# Altium schematic sheet lv_power_indicator_light_cc.SchDoc(lv_power_indicator_light_cc)
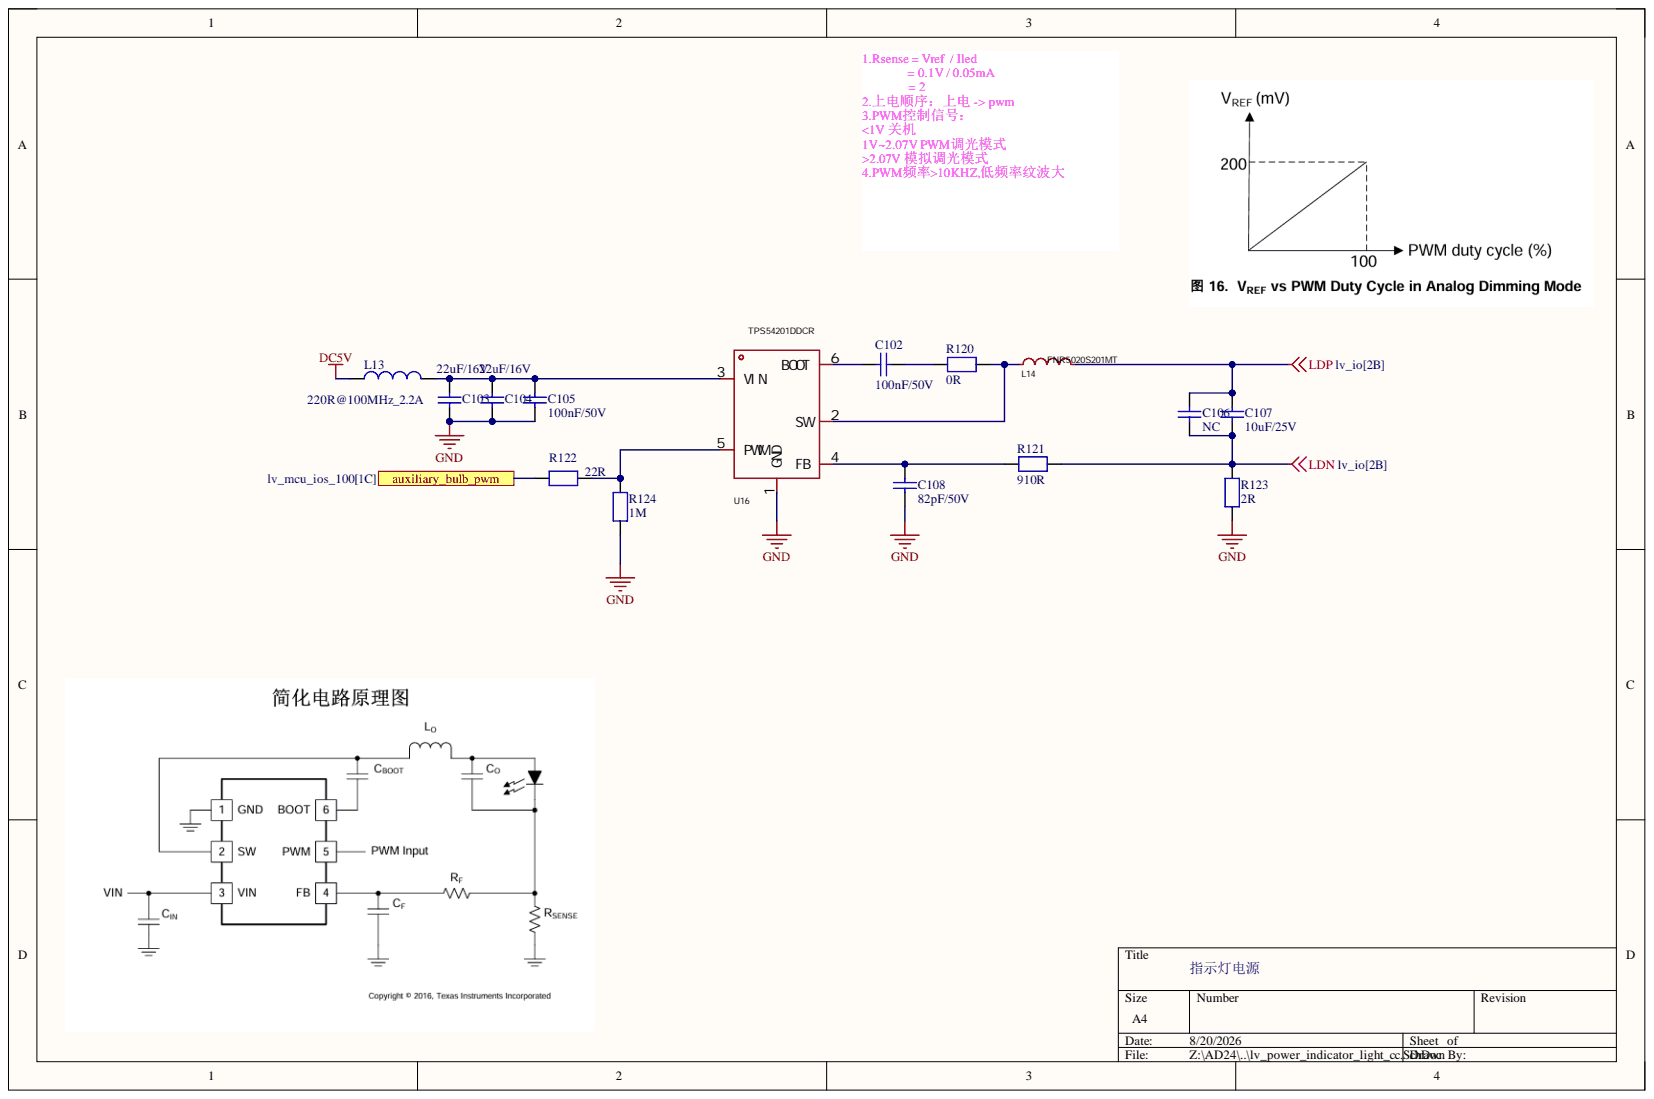
[diagram p0: lv_power_indicator_light_cc.SchDoc]

## Parts
C102  100nF/50V  [C0402]
C103  22uF/16V  [C1206]
C104  22uF/16V  [C1206]
C105  100nF/50V  [C0402]
C106  NC  [C0603]
C107  10uF/25V  [C0603]
C108  82pF/50V  [C0402]
L13  220R@100MHz_2.2A  [L0603]
L14  FNR5020S201MT  [IND-SMD_L5.0-W5.0_FNR50XXS]
R120  0R  [R0603]
R121  910R  [R0603]
R122  22R  [R0402]
R123  2R  [0805]
R124  1M  [R0603]
U16  TPS54201DDCR  [TSOT-23-6_L2.9-W1.6-P0.95-LS2.8-BR]

## Ports
auxiliary_bulb_pwm

## Nets
DC5V: L13.2
GND: C103.1 C104.1 C105.2 C108.2 R123.2 R124.1 U16.1(GND)
LDN: C106.1 C107.1 R121.1 R123.1
LDP: C106.2 C107.2 L14.2
auxiliary_bulb_pwm: R122.1 ; port auxiliary_bulb_pwm
NetC102_1: C102.1 U16.6(BOOT)
NetC102_2: C102.2 R120.2
NetC103_2: C103.2 C104.2 C105.1 L13.1 U16.3(VIN)
NetC108_1: C108.1 R121.2 U16.4(FB)
NetL14_1: L14.1 R120.1 U16.2(SW)
NetR122_2: R122.2 R124.2 U16.5(PWM)

## Library data of the parts
C102 = Capacitor: 50V, 5%, X7R; Manufacturer: muRata; Manufacturer_Part_Number: GRM155R71H104KE14D; Component Kind: Standard; ComponentLink1Description: Datasheet; ComponentLink1URL: http://tunmcdsvn.tun.st.com/Component_Datasheet_Library/Trunk/Capacitors/Yageo_Capacitor_NP0_16V-to-50V; Library Name: HiPin_Library_AD.IntLib
C103 C104 = Capacitor_1: 16V, X5R, 10%; Internal_Part_Number: MCD-00009; Manufacturer_Part_Number: GRM31CR61C226KE15L; Component Kind: Standard; ComponentLink1Description: Yageo_Capacitor_X5R-series.pdf; ComponentLink1URL: http://tunmcdsvn.tun.st.com/Component_Datasheet_Library/Trunk/Capacitors/Yageo_Multilayer_capacitor_X5R_series_100pF_to_100uF; Library Name: HiPin_Library_AD.IntLib
C105 = Capacitor_2: 50V, X7R , 10%; Manufacturer: muRata; Manufacturer_Part_Number: GRM155R71H104KE14D; Component Kind: Standard; ComponentLink1Description: Datasheet; ComponentLink1URL: http://tunmcdsvn.tun.st.com/Component_Datasheet_Library/Trunk/Capacitors/Yageo_Multilayer_capacitor_X5R_series_100pF_to_100uF; Library Name: HiPin_Library_AD.IntLib
C106 C107 = Capacitor_1: 25V, X5R, 20%; Manufacturer: muRata; Manufacturer_Part_Number: GRM188R61E106MA73D; Component Kind: Standard; ComponentLink1Description: Yageo_Capacitor_X5R-series.pdf; ComponentLink1URL: http://tunmcdsvn.tun.st.com/Component_Datasheet_Library/Trunk/Capacitors/Yageo_Multilayer_capacitor_X5R_series_100pF_to_100uF; Library Name: HiPin_Library_AD.IntLib
C108 = Capacitor: 50V,1%, NP0; Manufacturer: YAGEO; Manufacturer_Part_Number: CC0402FRNPO9BN820; Component Kind: Standard; Library Name: HiPin_Library_AD.IntLib
L13 = MCD-00047: SMD Chip ferrite bead, 220R@100MHz +/-25%,2.2A, 50mohm; Manufacturer: Sunlord; Manufacturer_Part_Number: UPZ1608U221-2R2TF; Database Table Name: MCD_PARTS$; Component Kind: Standard; ComponentLink1Description: Datasheet; ComponentLink1URL: http://tunmcdsvn.tun.st.com/Component_Datasheet_Library/Trunk/Inductors/TaiTech_Bead_FCM1608_series; Internal_Part_Number: MCD-00047; Library Name: MCD_PARTS_database.DbLib
L14 = FNR5020S201MT: 电感，330mA，2.6Ω，20%; Manufacturer: cjiang(长江微电); Add into BOM: yes; DC Resistance (DCR): 2.6Ω; Rated Current: 450mA; Saturation Current (Isat): 330mA; Tolerance: ±20%; Value: 200uH; Component Kind: Standard; Convert to PCB: yes; Datasheet: https://atta.szlcsc.com/upload/public/pdf/source/20190327/C167925_9EFF0AABC4CA629C2CC95961DBF7D39D.pdf; Device: FNR5020S201MT; JLCPCB Part Class: Extended Part; LCSC Part Name: 200uH ±20% 330mA; Library Name: HiPin_Library_AD.IntLib; Manufacturer Part: FNR5020S201MT; Supplier: LCSC; Supplier Footprint: SMD,5x5mm; Supplier Part: C167949; Symbol: FNR5020S201MT
R120-R124 = RES: 1%; Value: 10K; Component Kind: Standard; Library Name: HiPin_Library_AD.IntLib
U16 = TPS54201DDCR: 恒流源; Manufacturer: TI(德州仪器); Add into BOM: yes; Channels: 1; Topology: 降压; Component Kind: Standard; Convert to PCB: yes; Datasheet: https://www.ti.com/cn/lit/gpn/tps54201; Device: TPS54201DDCR; JLCPCB Part Class: Extended Part; LCSC Part Name: TPS54201DDCR; Library Name: HiPin_Library_AD.IntLib; Manufacturer Part: TPS54201DDCR; Operating temperature: -40℃~+125℃; Supplier: LCSC; Supplier Footprint: TSOT-23-6; Supplier Part: C841634; Symbol: TPS54201DDCR
